# Altium schematic sheet ZYNQ_ETH_PHY.SchDoc(ZYNQ_ETH_PHY)
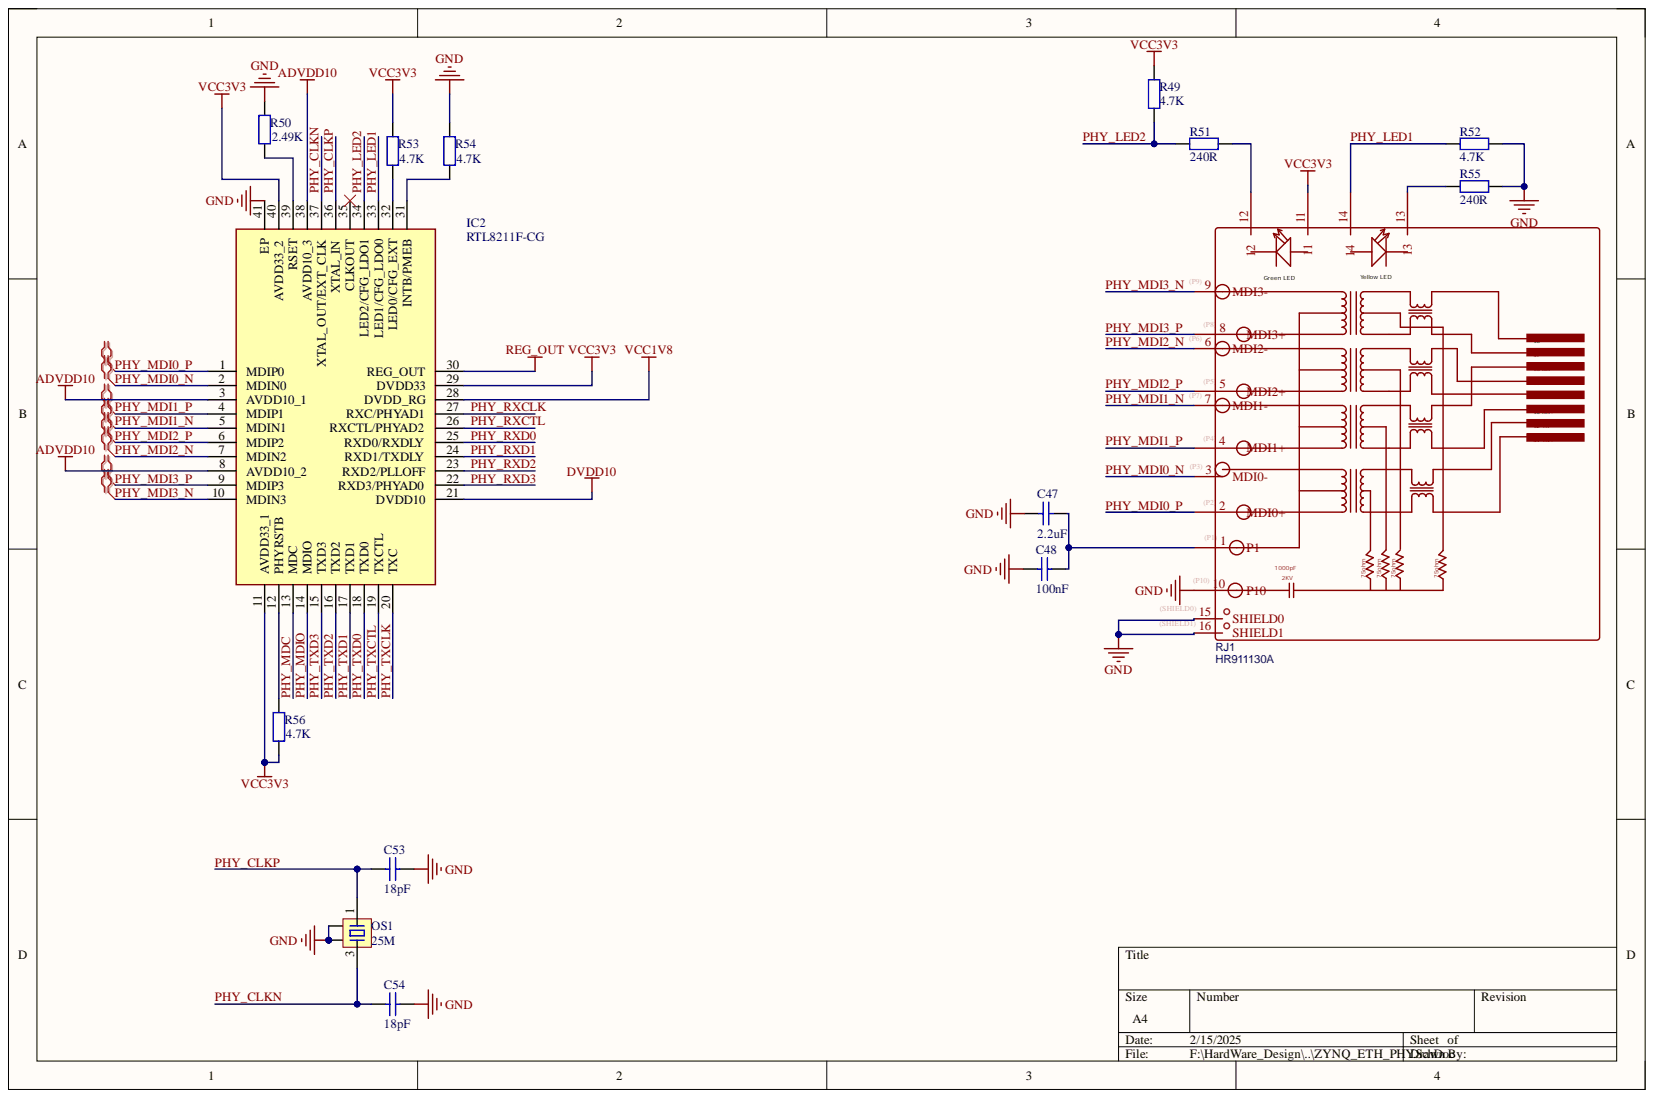
[diagram p0: ZYNQ_ETH_PHY.SchDoc]

## Parts
C47  2.2uF  [C 0402_L]
C48  100nF  [C 0402_L]
C53  18pF  [C 0402_L]
C54  18pF  [C 0402_L]
IC2  RTL8211F-CG  [QFN40P500X500X90-41N-D]
OS1  25M  [OSC 3225-4P]
R49  4.7K  [R 0402_L]
R50  2.49K  [R 0402_L]
R51  240R  [R 0402_L]
R52  4.7K  [R 0402_L]
R53  4.7K  [R 0402_L]
R54  4.7K  [R 0402_L]
R55  240R  [R 0402_L]
R56  4.7K  [R 0402_L]
RJ1  HR911130A  [HR911130A]

## Nets
ADVDD10: IC2.3(AVDD10_1) IC2.8(AVDD10_2) IC2.38(AVDD10_3)
DVDD10: IC2.21(DVDD10)
GND: C47.1 C48.1 C53.2 C54.2 IC2.41(EP) OS1.2 OS1.4 R50.2 R52.2 R54.2 R55.1 RJ1.P10 RJ1.SHIELD0 RJ1.SHIELD1
REG_OUT: IC2.30(REG_OUT)
VCC1V8: IC2.28(DVDD_RG)
VCC3V3: IC2.11(AVDD33_1) IC2.29(DVDD33) IC2.40(AVDD33_2) R49.1 R53.2 R56.1 RJ1.11
PHY_CLKN: C54.1 IC2.37(XTAL_OUT/EXT_CLK) OS1.3
PHY_CLKP: C53.1 IC2.36(XTAL_IN) OS1.1
PHY_LED1: IC2.33(LED1/CFG_LDO0) R52.1 RJ1.14
PHY_LED2: IC2.34(LED2/CFG_LDO1) R49.2 R51.2
PHY_MDC: IC2.13(MDC)
PHY_MDI0_N: IC2.2(MDIN0) RJ1.P3(MDI0-)
PHY_MDI0_P: IC2.1(MDIP0) RJ1.P2(MDI0+)
PHY_MDI1_N: IC2.5(MDIN1) RJ1.P7(MDI1-)
PHY_MDI1_P: IC2.4(MDIP1) RJ1.P4(MDI1+)
PHY_MDI2_N: IC2.7(MDIN2) RJ1.P6(MDI2-)
PHY_MDI2_P: IC2.6(MDIP2) RJ1.P5(MDI2+)
PHY_MDI3_N: IC2.10(MDIN3) RJ1.P9(MDI3-)
PHY_MDI3_P: IC2.9(MDIP3) RJ1.P8(MDI3+)
PHY_MDIO: IC2.14(MDIO)
PHY_RXCLK: IC2.27(RXC/PHYAD1)
PHY_RXCTL: IC2.26(RXCTL/PHYAD2)
PHY_RXD0: IC2.25(RXD0/RXDLY)
PHY_RXD1: IC2.24(RXD1/TXDLY)
PHY_RXD2: IC2.23(RXD2/PLLOFF)
PHY_RXD3: IC2.22(RXD3/PHYAD0)
PHY_TXCLK: IC2.20(TXC)
PHY_TXCTL: IC2.19(TXCTL)
PHY_TXD0: IC2.18(TXD0)
PHY_TXD1: IC2.17(TXD1)
PHY_TXD2: IC2.16(TXD2)
PHY_TXD3: IC2.15(TXD3)
NetC47_2: C47.2 C48.2 RJ1.P1
NetIC2_12: IC2.12(PHYRSTB) R56.2
NetIC2_31: IC2.31(INTB/PMEB) R54.1
NetIC2_32: IC2.32(LED0/CFG_EXT) R53.1
NetIC2_39: IC2.39(RSET) R50.1
NetR51_1: R51.1 RJ1.12
NetR55_2: R55.2 RJ1.13

Not connected: IC2 35(CLKOUT)

## Library data of the parts
C47 C48 = Cap: 贴片电容; Component Kind: Standard; Library Name: A_Schlib.SchLib
  C47: value: 2.2uF
  C48: value: 100nF
C53 C54 = Cap1: Component Kind: Standard; value: 18pF; Library Name: 零散元件库.SCHLIB
IC2 = RTL8211F-CG: Integrated Circuit; Manufacturer_Name: Realtek; Component Kind: Standard; Height: 0.9mm; Manufacturer_Part_Number: RTL8211F-CG
OS1 = XTAL-4P: 4脚无源晶振; 版权归属: 封装库来自淘宝卖家 ID：黄晶宝石; BOM名称: 4脚无源晶振; Component Kind: Standard; Library Name: A_Schlib.SchLib; 学习资源: https://shop463601444.taobao.com
R49-R56 = Res: Component Kind: Standard; Library Name: 零散元件库.SCHLIB
  R49 R52-R54 R56: value: 4.7K
  R50: value: 2.49K
  R51 R55: value: 240R
RJ1 = HR911130A_1: Manufacturer: HANRUN(汉仁); Component Kind: Standard; JLCPCB Part Class: Extended Part; Library Name: SamacSys.SchLib; Manufacturer Part: HR911130A; spicePre: R; spiceSymbolName: HR911130A; Supplier: LCSC; Supplier Part: C54408
